# Altium schematic sheet 056304_V2.SchDoc(056304_V2)
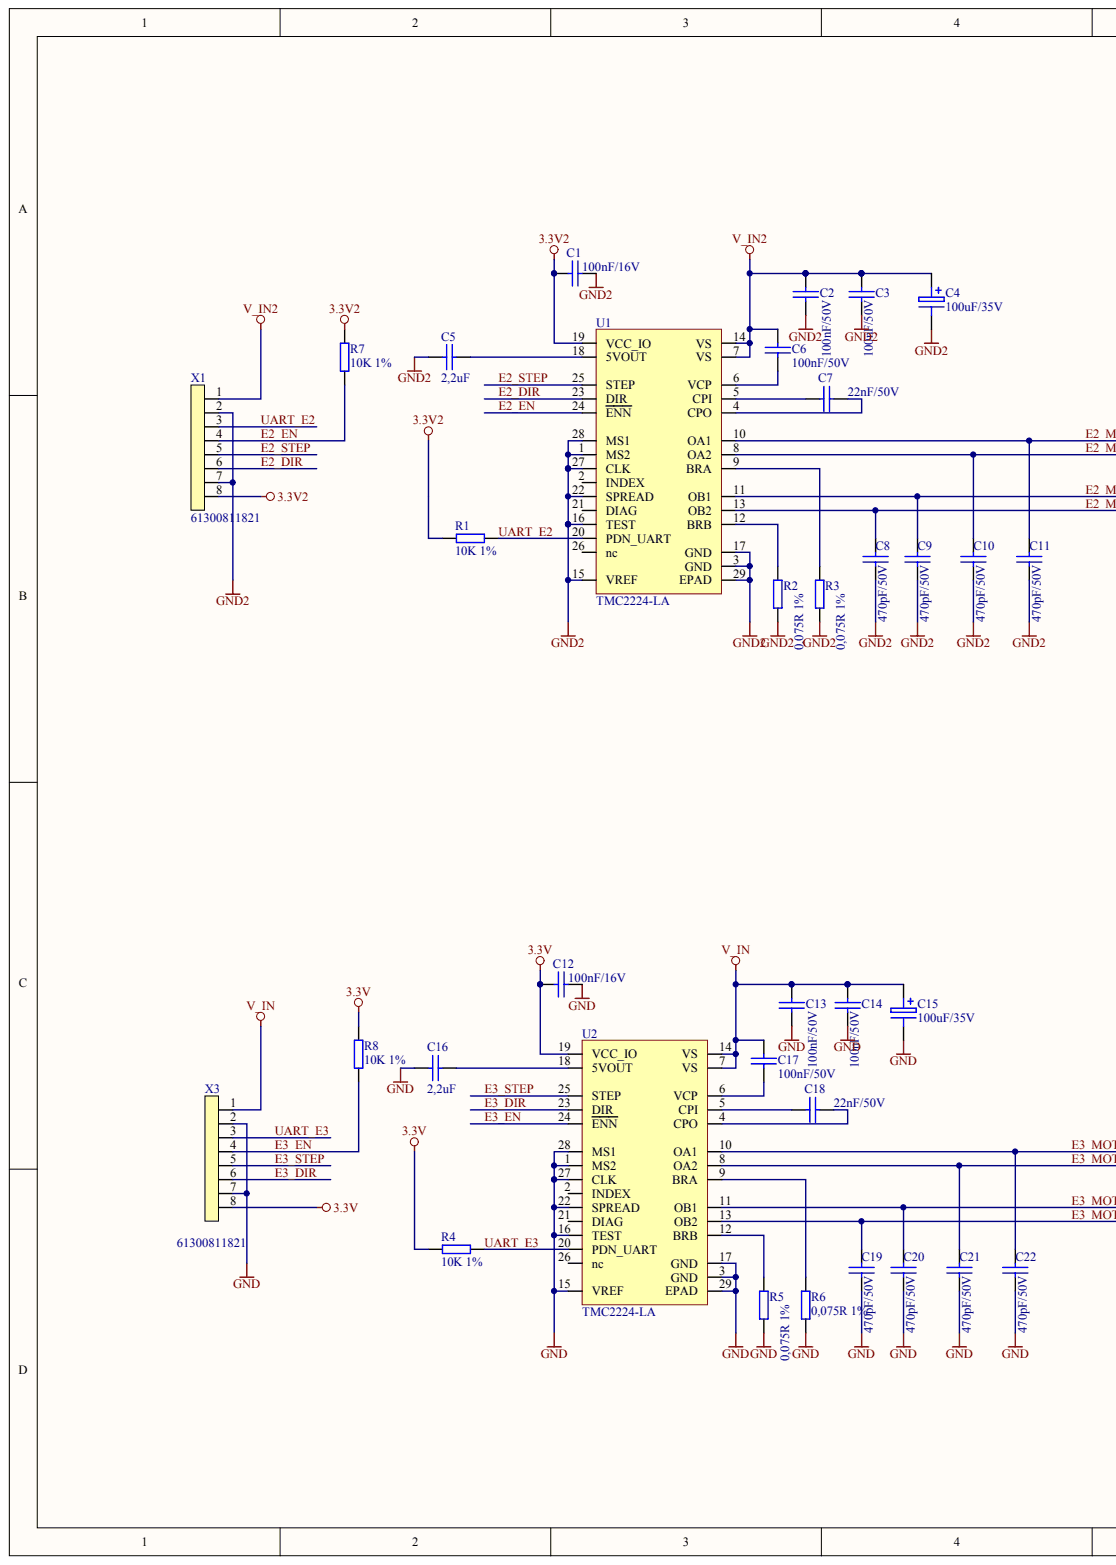
[diagram p0: 056304_V2.SchDoc, left]
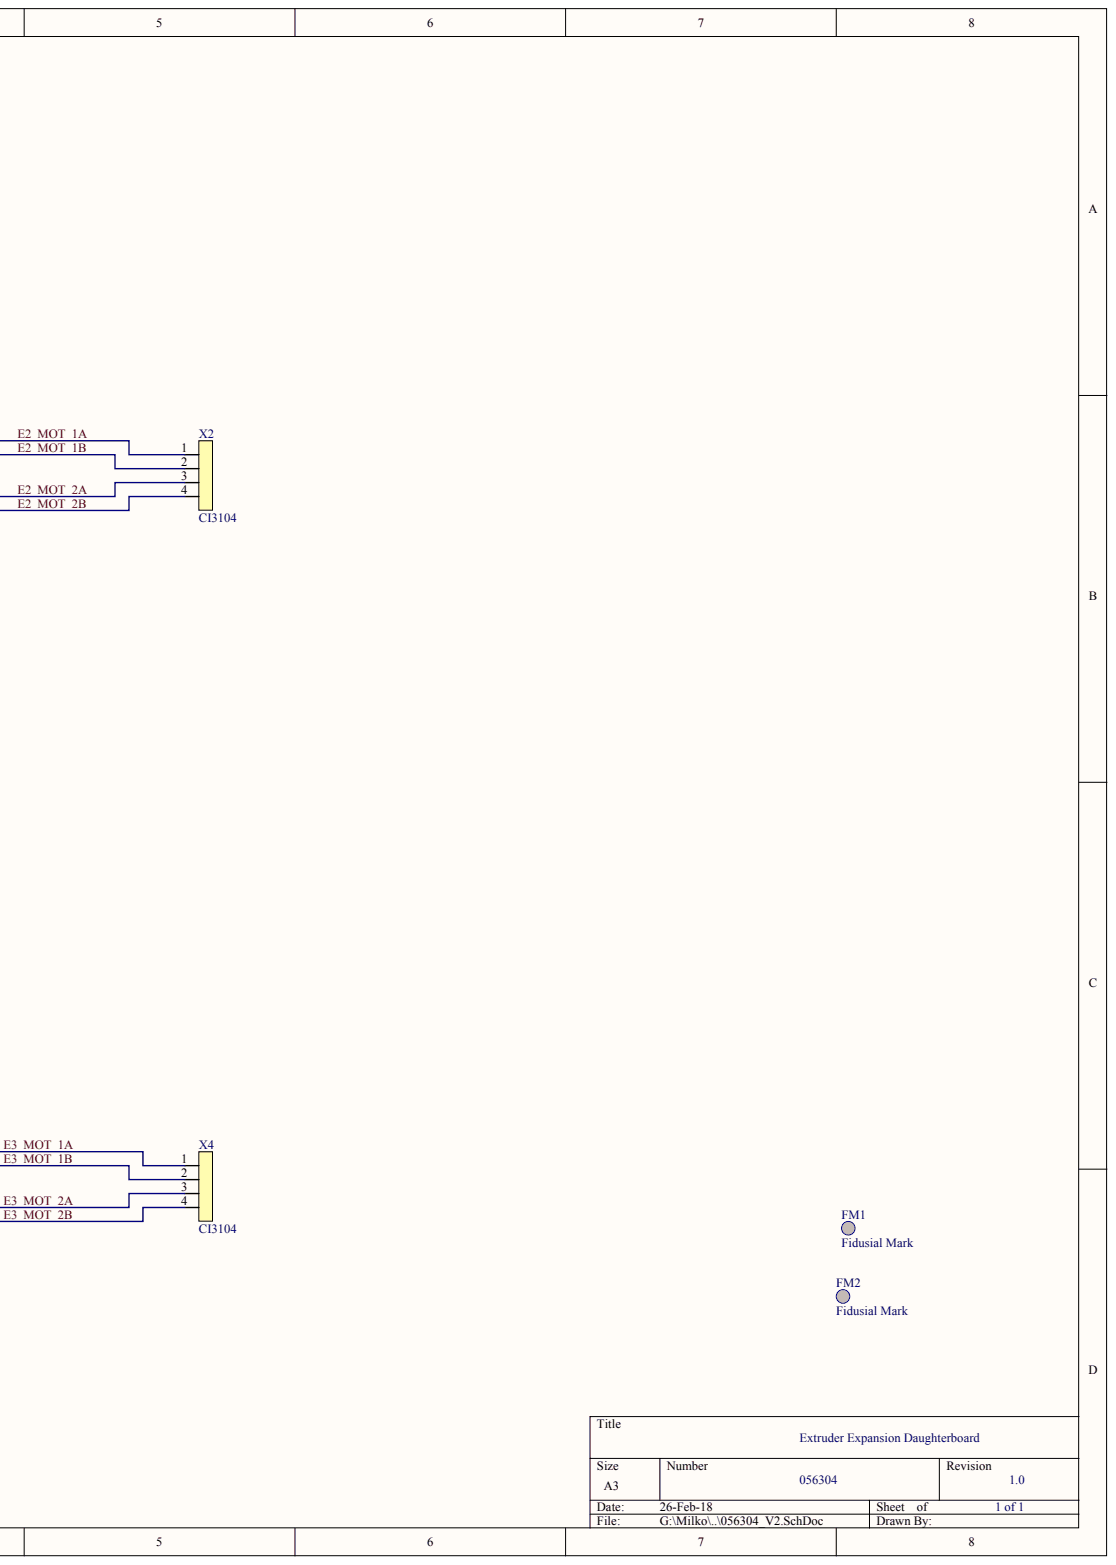
[diagram p1: 056304_V2.SchDoc, right]

## Parts
C1  100nF/16V
C2  100nF/50V
C3  100nF/50V
C4  100uF/35V
C5  2,2uF
C6  100nF/50V
C7  22nF/50V
C8  470pF/50V
C9  470pF/50V
C10  470pF/50V
C11  470pF/50V
C12  100nF/16V
C13  100nF/50V
C14  100nF/50V
C15  100uF/35V
C16  2,2uF
C17  100nF/50V
C18  22nF/50V
C19  470pF/50V
C20  470pF/50V
C21  470pF/50V
C22  470pF/50V
FM1  Fidusial Mark
FM2  Fidusial Mark
R1  10K 1%
R2  0,075R 1%
R3  0,075R 1%
R4  10K 1%
R5  0,075R 1%
R6  0,075R 1%
R7  10K 1%
R8  10K 1%
U1  TMC2224-LA
U2  TMC2224-LA
X1  61300811821
X2  CI3104
X3  61300811821
X4  CI3104

## Nets
3.3V: C12.2 R4.2 R8.1 U2.19(VCC_IO) X3.8
3.3V2: C1.2 R1.2 R7.1 U1.19(VCC_IO) X1.8
GND: C12.1 C13.2 C14.2 C15.2 C16.1 C19.2 C20.2 C21.2 C22.2 R5.1 R6.1 U2.1(MS2) U2.3(GND) U2.15(VREF) U2.16(TEST) U2.17(GND) U2.22(SPREAD) U2.27(CLK) U2.28(MS1) U2.29(EPAD) X3.2 X3.7
GND2: C1.1 C2.2 C3.2 C4.2 C5.1 C8.2 C9.2 C10.2 C11.2 R2.1 R3.1 U1.1(MS2) U1.3(GND) U1.15(VREF) U1.16(TEST) U1.17(GND) U1.22(SPREAD) U1.27(CLK) U1.28(MS1) U1.29(EPAD) X1.2 X1.7
V_IN: C13.1 C14.1 C15.1 C17.2 U2.7(VS) U2.14(VS) X3.1
V_IN2: C2.1 C3.1 C4.1 C6.2 U1.7(VS) U1.14(VS) X1.1
E2_DIR: U1.23(DIR) X1.6
E2_EN: R7.2 U1.24(ENN) X1.4
E2_MOT_1A: C11.1 U1.10(OA1) X2.1
E2_MOT_1B: C10.1 U1.8(OA2) X2.2
E2_MOT_2A: C9.1 U1.11(OB1) X2.3
E2_MOT_2B: C8.1 U1.13(OB2) X2.4
E2_STEP: U1.25(STEP) X1.5
E3_DIR: U2.23(DIR) X3.6
E3_EN: R8.2 U2.24(ENN) X3.4
E3_MOT_1A: C22.1 U2.10(OA1) X4.1
E3_MOT_1B: C21.1 U2.8(OA2) X4.2
E3_MOT_2A: C20.1 U2.11(OB1) X4.3
E3_MOT_2B: C19.1 U2.13(OB2) X4.4
E3_STEP: U2.25(STEP) X3.5
UART_E2: R1.1 U1.20(PDN_UART) X1.3
UART_E3: R4.1 U2.20(PDN_UART) X3.3
NetC5_2: C5.2 U1.18(5VOUT)
NetC6_1: C6.1 U1.6(VCP)
NetC7_1: C7.1 U1.5(CPI)
NetC7_2: C7.2 U1.4(CPO)
NetC16_2: C16.2 U2.18(5VOUT)
NetC17_1: C17.1 U2.6(VCP)
NetC18_1: C18.1 U2.5(CPI)
NetC18_2: C18.2 U2.4(CPO)
NetR2_2: R2.2 U1.12(BRB)
NetR3_2: R3.2 U1.9(BRA)
NetR5_2: R5.2 U2.12(BRB)
NetR6_2: R6.2 U2.9(BRA)

Not connected: U1 2(INDEX) 21(DIAG) 26(nc); U2 2(INDEX) 21(DIAG) 26(nc)

## Library data of the parts
C2 C3 C6 C13 C14 C17 = (no symbol name): Manufacturer: Kemet; Part Number: C0805C104K5RACTU; Mouser: 80-C0805C104K5R
C4 C15 = (no symbol name): Manufacturer: Wurth; Part Number: 865080545012; Mouser: 710-865080545012
C7 C18 = (no symbol name): Part Number: C0805C223K5RAC; Manufacturer: Kemet; Mouser: 80-C0805C223K5RAC
C8-C11 C19-C22 = (no symbol name): Part Number: VJ0603Y471KXACW1BC; Manufacturer: Vishay; Mouser: 77-VJ0603Y471KXACBC
R2 R3 R5 R6 = (no symbol name): Manufacturer: Yageo; Part Number: RL1206FR-070R075L; Mouser: 603-RL1206FR070R075L
U1 U2 = (no symbol name): Manufacturer: TRINAMIC; Part Number: TMC224-LA; Digikey: 1460-1216-1-ND
X1 X3 = (no symbol name): Manufacturer: Wurth; Part Number: 61300811821; Mouser: xx
X2 = (no symbol name): Manufacturer: CVILUX; Part Number: CI3104P1V00; Alternate Part: Wurth 61900411121; Mouser: 710-61900411121
X4 = (no symbol name): Manufacturer: CVILUX; Part Number: CI3104P1V00
